# Altium schematic sheet Buttons.SchDoc(Buttons)
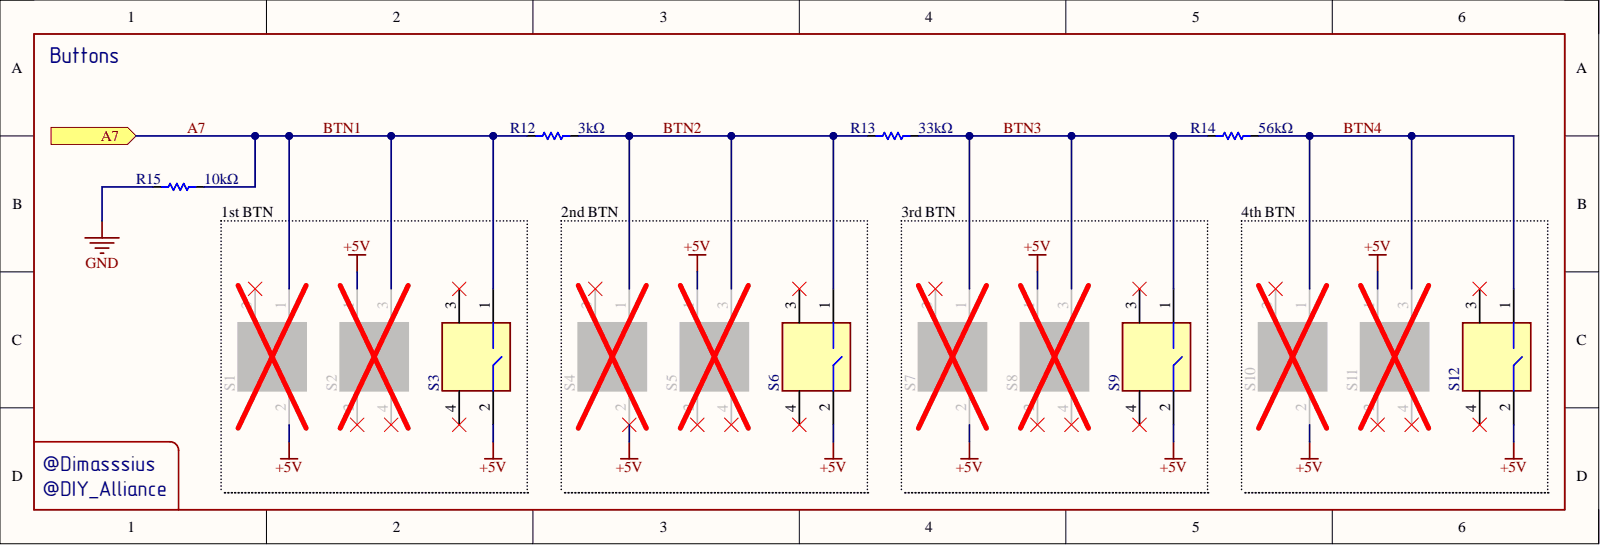
[diagram p0: Buttons.SchDoc]

## Parts
R12  3kΩ  [RES_THD/SMD_VERTICAL_R0603_R0402_3K_THD]
R12
R12
R13  33kΩ  [RES_THD/SMD_VERTICAL_R0603_R0402_33K_THD]
R13
R13
R14  56kΩ  [RES_THD/SMD_VERTICAL_R0603_R0402_56K_THD]
R14
R14
R15  10kΩ  [RES_THD/SMD_VERTICAL_R0603_R0402_10K_THD]
R15
R15
S1  TS-1009B  [TS-1009B]  (value not drawn)
S2  TS-1187A-B-A-B  [TS-1187A-B-A-B]  (value not drawn)
S3  EVQPF108K  [EVQPF008K]  (value not drawn)
S4  TS-1009B  [TS-1009B]  (value not drawn)
S5  TS-1187A-B-A-B  [TS-1187A-B-A-B]  (value not drawn)
S6  EVQPF108K  [EVQPF008K]  (value not drawn)
S7  TS-1009B  [TS-1009B]  (value not drawn)
S8  TS-1187A-B-A-B  [TS-1187A-B-A-B]  (value not drawn)
S9  EVQPF108K  [EVQPF008K]  (value not drawn)
S10  TS-1009B  [TS-1009B]  (value not drawn)
S11  TS-1187A-B-A-B  [TS-1187A-B-A-B]  (value not drawn)
S12  EVQPF108K  [EVQPF008K]  (value not drawn)

## Ports
A7

## Nets
+5V: S1.2 S2.1 S3.2 S4.2 S5.1 S6.2 S7.2 S8.1 S9.2 S10.2 S11.1 S12.2
GND: R15.1
A7: R12.1 R15.2 S1.1 S2.3 S3.1 ; port A7
BTN2: R12.2 R13.1 S4.1 S5.3 S6.1
BTN3: R13.2 R14.1 S7.1 S8.3 S9.1
BTN4: R14.2 S10.1 S11.3 S12.1

Not connected: S1 3; S2 2 4; S3 3 4; S4 3; S5 2 4; S6 3 4; S7 3; S8 2 4; S9 3 4; S10 3; S11 2 4; S12 3 4

## Library data of the parts
R12 = RES_THD/SMD_3KΩ_R0603_R0402_THD: Resistor, Metal Film Resistor, Through Hole, D2.3xL6.5mm, 3kΩ, ±1%, 250mW, Through Hole Resistors, ROHS; Component Kind: Standard; Library Name: Resistors.SchLib; Value: 3kΩ
R13 = RES_THD/SMD_33KΩ_R0603_R0402_THD: Resistor, Metal Film Resistor, Through Hole, D2.3xL6.5mm, 33kΩ, ±1%, 250mW, Through Hole Resistors, ROHS; Component Kind: Standard; Library Name: Resistors.SchLib; Value: 33kΩ
R14 = RES_THD/SMD_56KΩ_R0603_R0402_THD: Resistor, Metal Film Resistor, Through Hole, D2.3xL6.5mm, 56kΩ, ±1%, 250mW, Through Hole Resistors, ROHS; Component Kind: Standard; Library Name: Resistors.SchLib; Value: 56kΩ
R15 = RES_THD/SMD_10KΩ_R0603_R0402_THD: Resistor, Metal Film Resistor, Through Hole, D2.3xL6.5mm, 10kΩ, ±1%, 250mW, Through Hole Resistors, ROHS; Component Kind: Standard; Library Name: Resistors.SchLib; Value: 10kΩ
S1 S4 S7 S10 = TS-1009B: Switch Tactile, Through Hole, 4.5x4.5mm, Round Button, 50mA, Bend-in 4.5mm, SPST, 12V, Plugin, Tactile Switches, ROHS; Component Kind: Standard; Datasheet Link: https://www.mouser.com/datasheet/2/140/TL59-TL58-346345-1369262.pdf; Height: 5mm; Library Name: SamacSys.SchLib; Value: TS-1009B
S2 S5 S8 S11 = TS-1187A-B-A-B: Switch Tactile, SMD, 5.1x5.1mm, Without bracket, 50mA, 100000 Times, 160gf, 12V, Round, SMD Tactile Switches, ROHS; Component Kind: Standard; Library Name: SamacSys.SchLib; Package: None; Value: TS-1187A-B-A-B
S3 S6 S9 S12 = TS665ZJ: Switch Tactile, Through Hole, 6.2mm, 5mm, With bracket, 50mA, Round Button, Bend-in 6.2mm, SPST 50,000 times, 12V, 250gf, Plugin Tactile Switches, ROHS; Component Kind: Standard; Datasheet Link: https://datasheet.datasheetarchive.com/originals/distributors/Datasheets-28/DSA-557100.pdf; Height: 7mm; Library Name: SamacSys.SchLib; Value: EVQPF108K
